# Altium schematic sheet AD9363.SchDoc(AD9363)
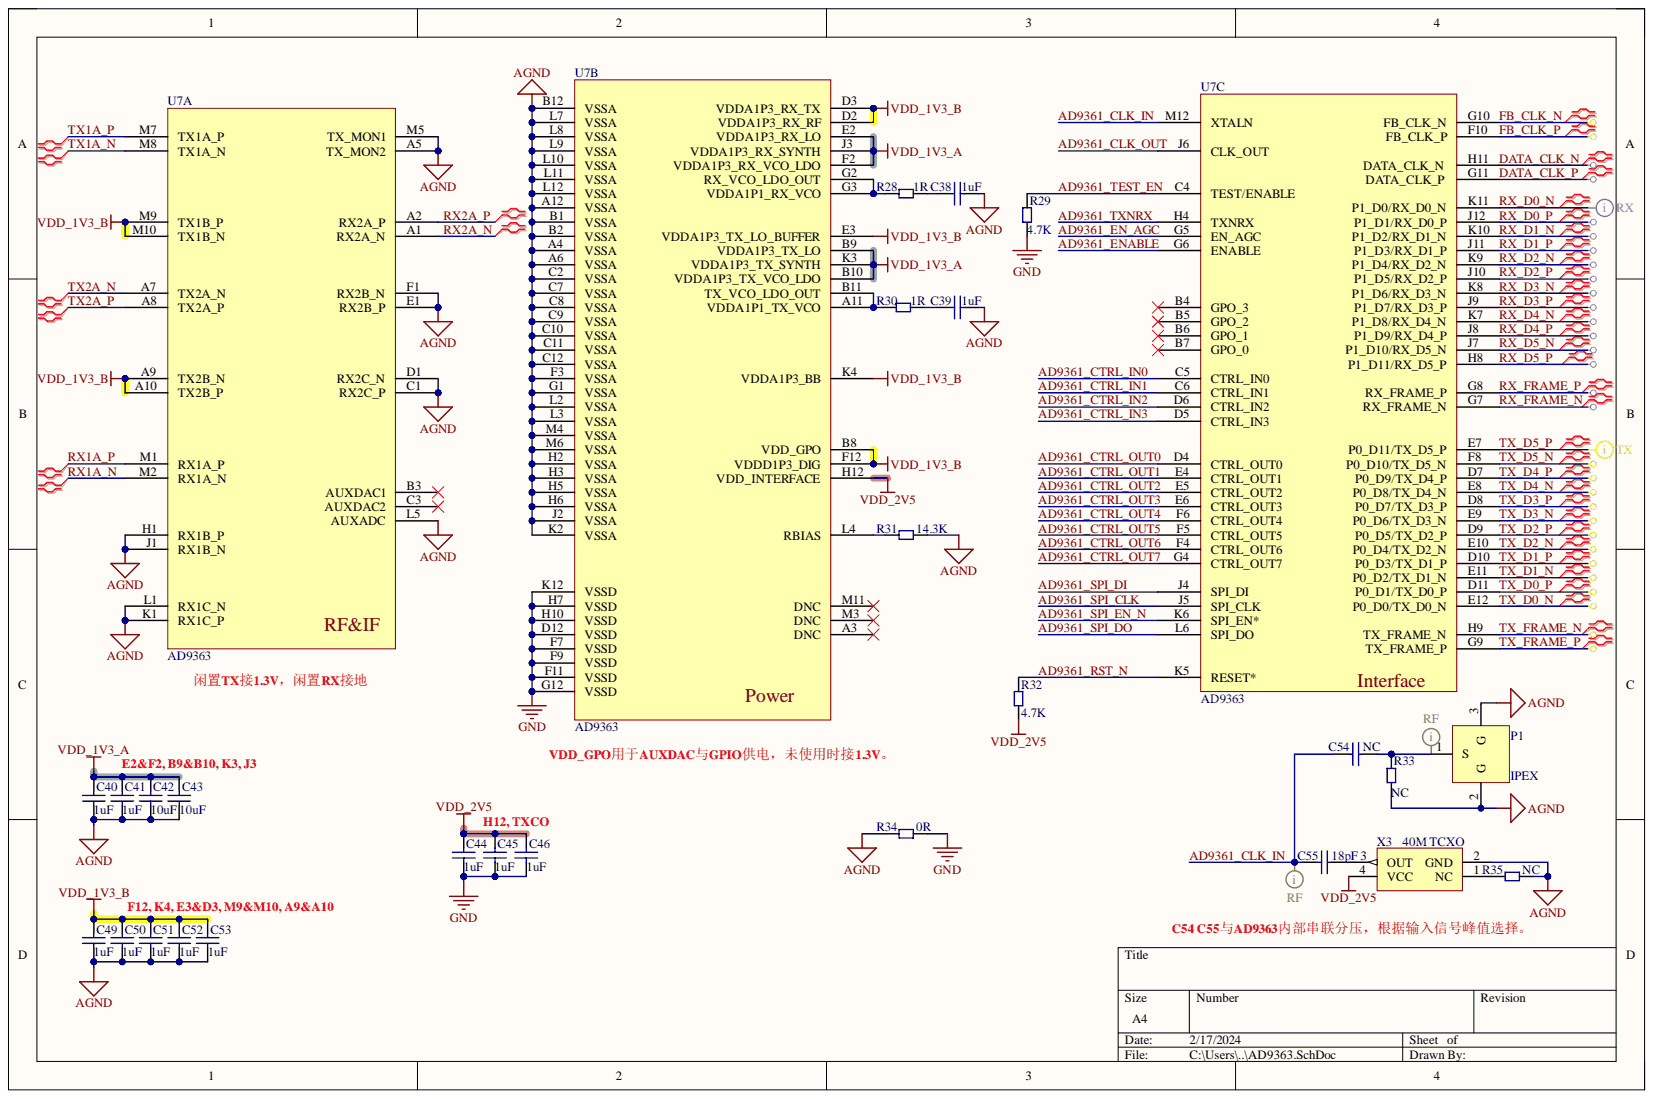
[diagram p0: AD9363.SchDoc]

## Parts
C38  1uF  [C0402]
C39  1uF  [C0402]
C40  1uF  [C0402]
C41  1uF  [C0402]
C42  10uF  [C0402]
C43  10uF  [C0402]
C44  1uF  [C0402]
C45  1uF  [C0402]
C46  1uF  [C0402]
C49  1uF  [C0402]
C50  1uF  [C0402]
C51  1uF  [C0402]
C52  1uF  [C0402]
C53  1uF  [C0402]
C54  NC  [C0402]
C55  18pF  [C0402]
P1  IPEX  [IPEX_SMD]
R28  1R  [R0402]
R29  4.7K  [R0402]
R30  1R  [R0402]
R31  14.3K  [R0402]
R32  4.7K  [R0402]
R33  NC  [R0402]
R34  0R  [R0402]
R35  NC  [R0402]
U7  AD9363  [BGA-144]  (parts A,B,C)
X3  40M TCXO  [SMD-3225_4P]

## Nets
AGND: C38.2 C39.2 C40.1 C41.1 C42.1 C43.1 C49.1 C50.1 C51.1 C52.1 C53.1 P1.2 P1.3 R31.2 R33.1 R34.1 R35.2 U7.A4(VSSA) U7.A5(TX_MON2) U7.A6(VSSA) U7.A12(VSSA) U7.B1(VSSA) U7.B2(VSSA) U7.B12(VSSA) U7.C1(RX2C_P) U7.C2(VSSA) U7.C7(VSSA) U7.C8(VSSA) U7.C9(VSSA) U7.C10(VSSA) U7.C11(VSSA) U7.C12(VSSA) U7.D1(RX2C_N) U7.E1(RX2B_P) U7.F1(RX2B_N) U7.F3(VSSA) U7.G1(VSSA) U7.H1(RX1B_P) U7.H2(VSSA) U7.H3(VSSA) U7.H5(VSSA) U7.H6(VSSA) U7.J1(RX1B_N) U7.J2(VSSA) U7.K1(RX1C_P) U7.K2(VSSA) U7.L1(RX1C_N) U7.L2(VSSA) U7.L3(VSSA) U7.L5(AUXADC) U7.L7(VSSA) U7.L8(VSSA) U7.L9(VSSA) U7.L10(VSSA) U7.L11(VSSA) U7.L12(VSSA) U7.M4(VSSA) U7.M5(TX_MON1) U7.M6(VSSA) X3.2(GND)
GND: C44.1 C45.1 C46.1 R29.2 R34.2 U7.D12(VSSD) U7.F7(VSSD) U7.F9(VSSD) U7.F11(VSSD) U7.G12(VSSD) U7.H7(VSSD) U7.H10(VSSD) U7.K12(VSSD)
VDD_1V3_A: C40.2 C41.2 C42.2 C43.2 U7.B9(VDDA1P3_TX_LO) U7.B10(VDDA1P3_TX_VCO_LDO) U7.E2(VDDA1P3_RX_LO) U7.F2(VDDA1P3_RX_VCO_LDO) U7.J3(VDDA1P3_RX_SYNTH) U7.K3(VDDA1P3_TX_SYNTH)
VDD_1V3_B: C49.2 C50.2 C51.2 C52.2 C53.2 U7.A9(TX2B_N) U7.A10(TX2B_P) U7.B8(VDD_GPO) U7.D2(VDDA1P3_RX_RF) U7.D3(VDDA1P3_RX_TX) U7.E3(VDDA1P3_TX_LO_BUFFER) U7.F12(VDDD1P3_DIG) U7.K4(VDDA1P3_BB) U7.M9(TX1B_P) U7.M10(TX1B_N)
VDD_2V5: C44.2 C45.2 C46.2 R32.1 U7.H12(VDD_INTERFACE) X3.4(VCC)
AD9361_CLK_IN: C54.2 C55.1 U7.M12(XTALN)
AD9361_CLK_OUT: U7.J6(CLK_OUT)
AD9361_CTRL_IN0: U7.C5(CTRL_IN0)
AD9361_CTRL_IN1: U7.C6(CTRL_IN1)
AD9361_CTRL_IN2: U7.D6(CTRL_IN2)
AD9361_CTRL_IN3: U7.D5(CTRL_IN3)
AD9361_CTRL_OUT0: U7.D4(CTRL_OUT0)
AD9361_CTRL_OUT1: U7.E4(CTRL_OUT1)
AD9361_CTRL_OUT2: U7.E5(CTRL_OUT2)
AD9361_CTRL_OUT3: U7.E6(CTRL_OUT3)
AD9361_CTRL_OUT4: U7.F6(CTRL_OUT4)
AD9361_CTRL_OUT5: U7.F5(CTRL_OUT5)
AD9361_CTRL_OUT6: U7.F4(CTRL_OUT6)
AD9361_CTRL_OUT7: U7.G4(CTRL_OUT7)
AD9361_EN_AGC: U7.G5(EN_AGC)
AD9361_ENABLE: U7.G6(ENABLE)
AD9361_RST_N: R32.2 U7.K5(RESET*)
AD9361_SPI_CLK: U7.J5(SPI_CLK)
AD9361_SPI_DI: U7.J4(SPI_DI)
AD9361_SPI_DO: U7.L6(SPI_DO)
AD9361_SPI_EN_N: U7.K6(SPI_EN*)
AD9361_TEST_EN: R29.1 U7.C4(TEST/ENABLE)
AD9361_TXNRX: U7.H4(TXNRX)
DATA_CLK_N: U7.H11(DATA_CLK_N)
DATA_CLK_P: U7.G11(DATA_CLK_P)
FB_CLK_N: U7.G10(FB_CLK_N)
FB_CLK_P: U7.F10(FB_CLK_P)
RX1A_N: U7.M2(RX1A_N)
RX1A_P: U7.M1(RX1A_P)
RX2A_N: U7.A1(RX2A_N)
RX2A_P: U7.A2(RX2A_P)
RX_D0_N: U7.K11(P1_D0/RX_D0_N)
RX_D0_P: U7.J12(P1_D1/RX_D0_P)
RX_D1_N: U7.K10(P1_D2/RX_D1_N)
RX_D1_P: U7.J11(P1_D3/RX_D1_P)
RX_D2_N: U7.K9(P1_D4/RX_D2_N)
RX_D2_P: U7.J10(P1_D5/RX_D2_P)
RX_D3_N: U7.K8(P1_D6/RX_D3_N)
RX_D3_P: U7.J9(P1_D7/RX_D3_P)
RX_D4_N: U7.K7(P1_D8/RX_D4_N)
RX_D4_P: U7.J8(P1_D9/RX_D4_P)
RX_D5_N: U7.J7(P1_D10/RX_D5_N)
RX_D5_P: U7.H8(P1_D11/RX_D5_P)
RX_FRAME_N: U7.G7(RX_FRAME_N)
RX_FRAME_P: U7.G8(RX_FRAME_P)
TX1A_N: U7.M8(TX1A_N)
TX1A_P: U7.M7(TX1A_P)
TX2A_N: U7.A7(TX2A_N)
TX2A_P: U7.A8(TX2A_P)
TX_D0_N: U7.E12(P0_D0/TX_D0_N)
TX_D0_P: U7.D11(P0_D1/TX_D0_P)
TX_D1_N: U7.E11(P0_D2/TX_D1_N)
TX_D1_P: U7.D10(P0_D3/TX_D1_P)
TX_D2_N: U7.E10(P0_D4/TX_D2_N)
TX_D2_P: U7.D9(P0_D5/TX_D2_P)
TX_D3_N: U7.E9(P0_D6/TX_D3_N)
TX_D3_P: U7.D8(P0_D7/TX_D3_P)
TX_D4_N: U7.E8(P0_D8/TX_D4_N)
TX_D4_P: U7.D7(P0_D9/TX_D4_P)
TX_D5_N: U7.F8(P0_D10/TX_D5_N)
TX_D5_P: U7.E7(P0_D11/TX_D5_P)
TX_FRAME_N: U7.H9(TX_FRAME_N)
TX_FRAME_P: U7.G9(TX_FRAME_P)
NetC38_1: C38.1 R28.2
NetC39_1: C39.1 R30.2
NetC54_1: C54.1 P1.1 R33.2
NetC55_2: C55.2 X3.3(OUT)
NetR28_1: R28.1 U7.G2(RX_VCO_LDO_OUT) U7.G3(VDDA1P1_RX_VCO)
NetR30_1: R30.1 U7.A11(VDDA1P1_TX_VCO) U7.B11(TX_VCO_LDO_OUT)
NetR31_1: R31.1 U7.L4(RBIAS)
NetR35_1: R35.1 X3.1(NC)

Not connected: U7 A3(DNC) B3(AUXDAC1) B4(GPO_3) B5(GPO_2) B6(GPO_1) B7(GPO_0) C3(AUXDAC2) M3(DNC) M11(DNC)

## Library data of the parts
C38-C46 C49-C55 = Cap_NP: CAP no polarity; Component Kind: Standard; Library Name: Common.SchLib
R28-R35 = Res: Component Kind: Standard; Description: Res; Library Name: Common.SchLib
U7 = AD9363: 70MHz-6GHz Transceiver; Component Kind: Standard; Library Name: ZYNQ_SDR.SCHLIB
X3 = XTAL-Active: Oscillator; Component Kind: Standard; Library Name: Common.SchLib
P1 = IPEX: Component Kind: Standard; Library Name: Common.SchLib
